# Altium schematic sheet p02_Power.SchDoc
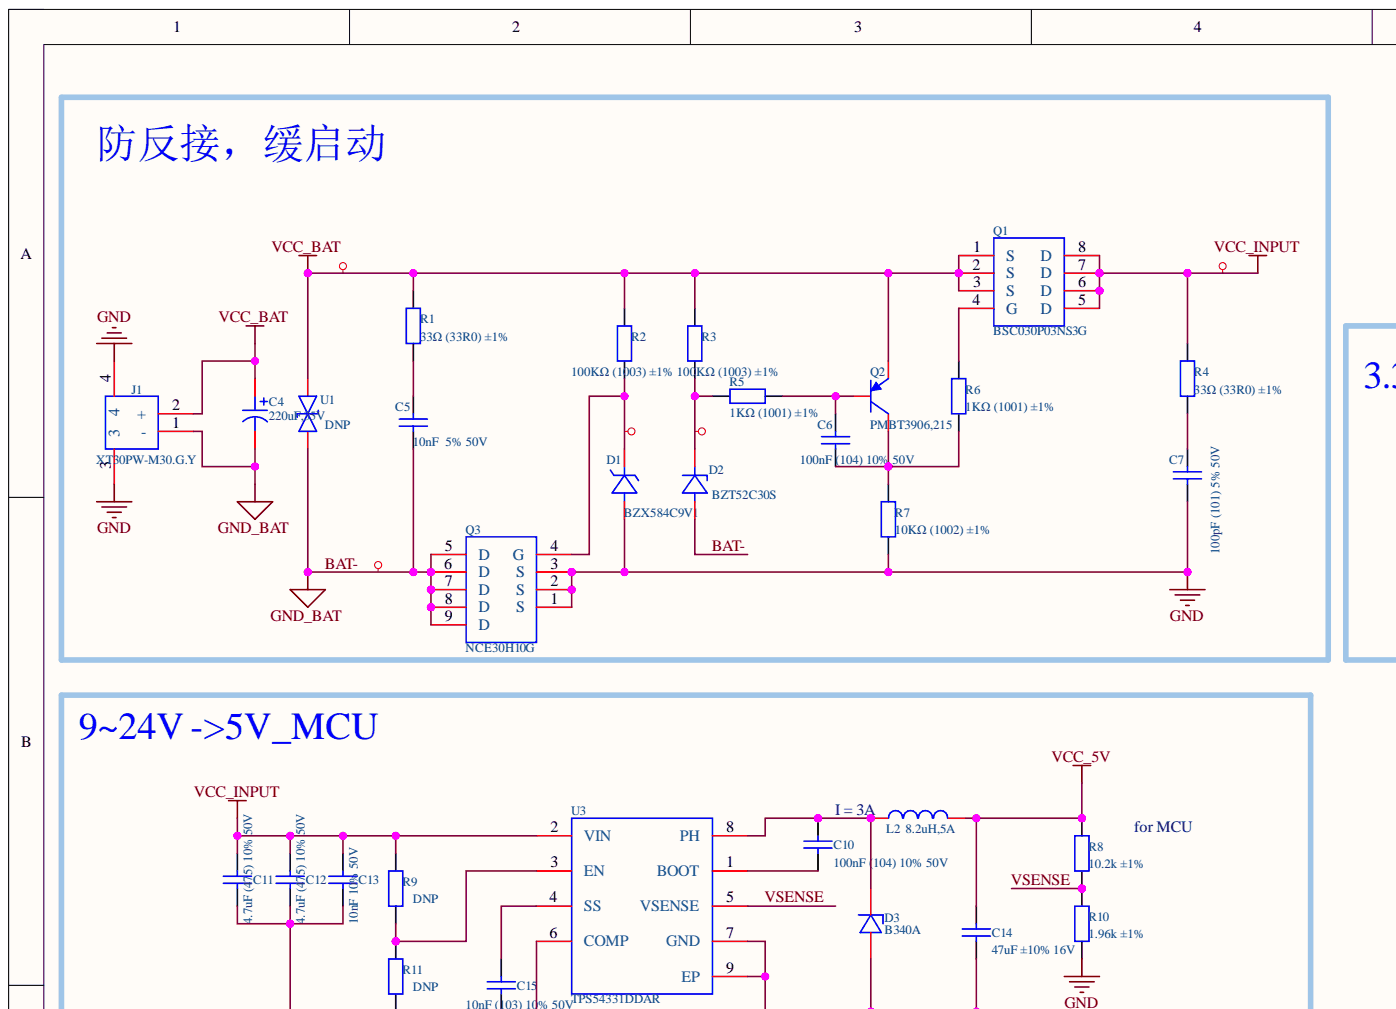
[diagram p0: p02_Power.SchDoc, top left]
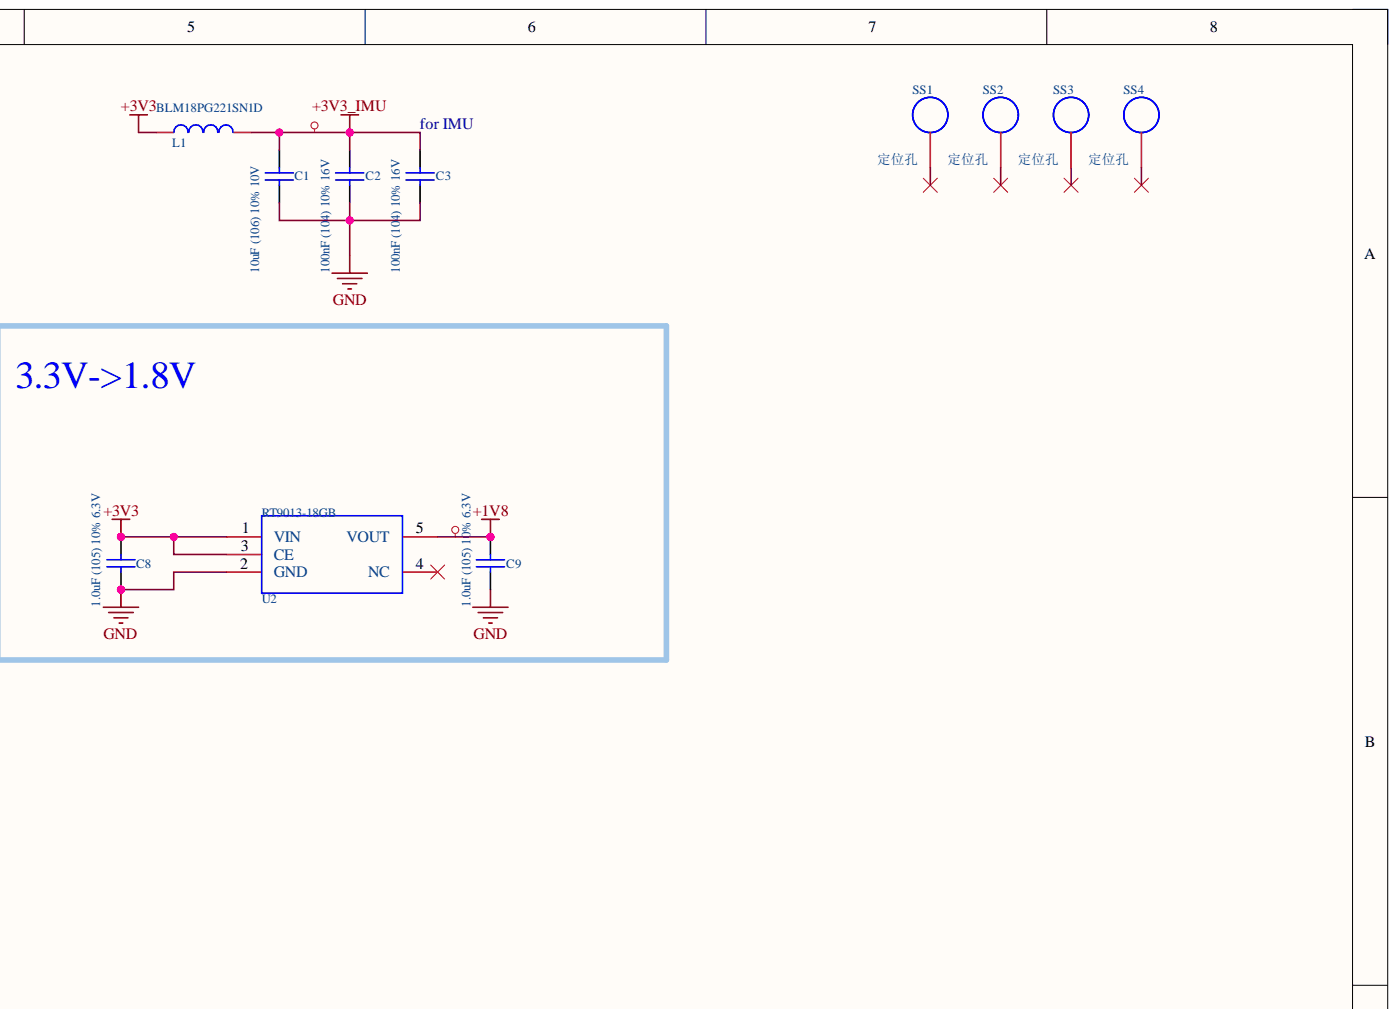
[diagram p1: p02_Power.SchDoc, top right]
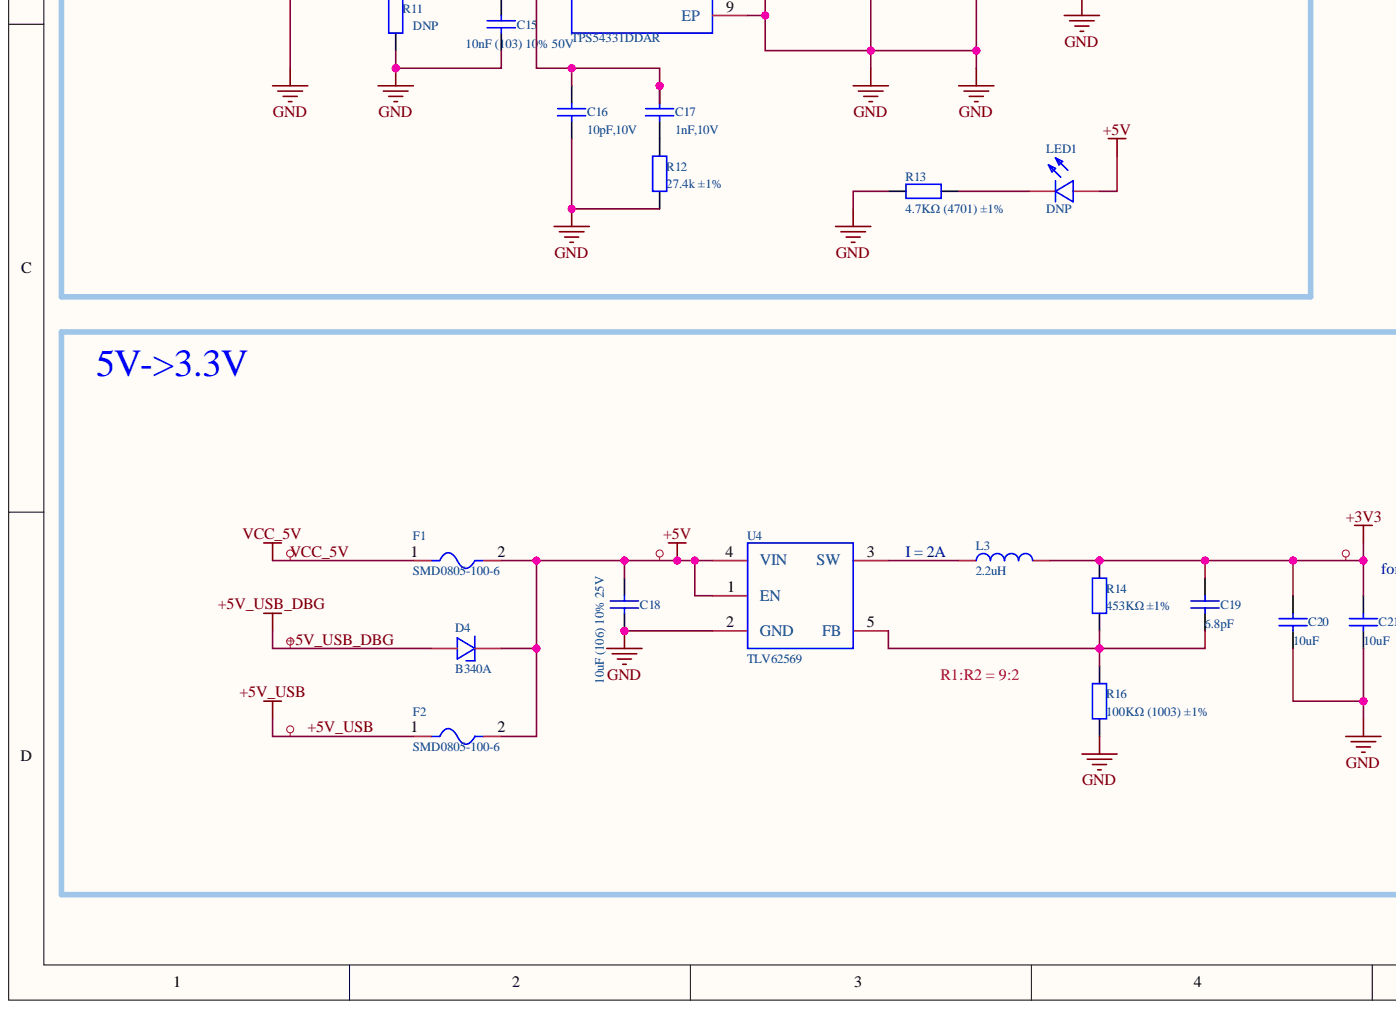
[diagram p2: p02_Power.SchDoc, bottom left]
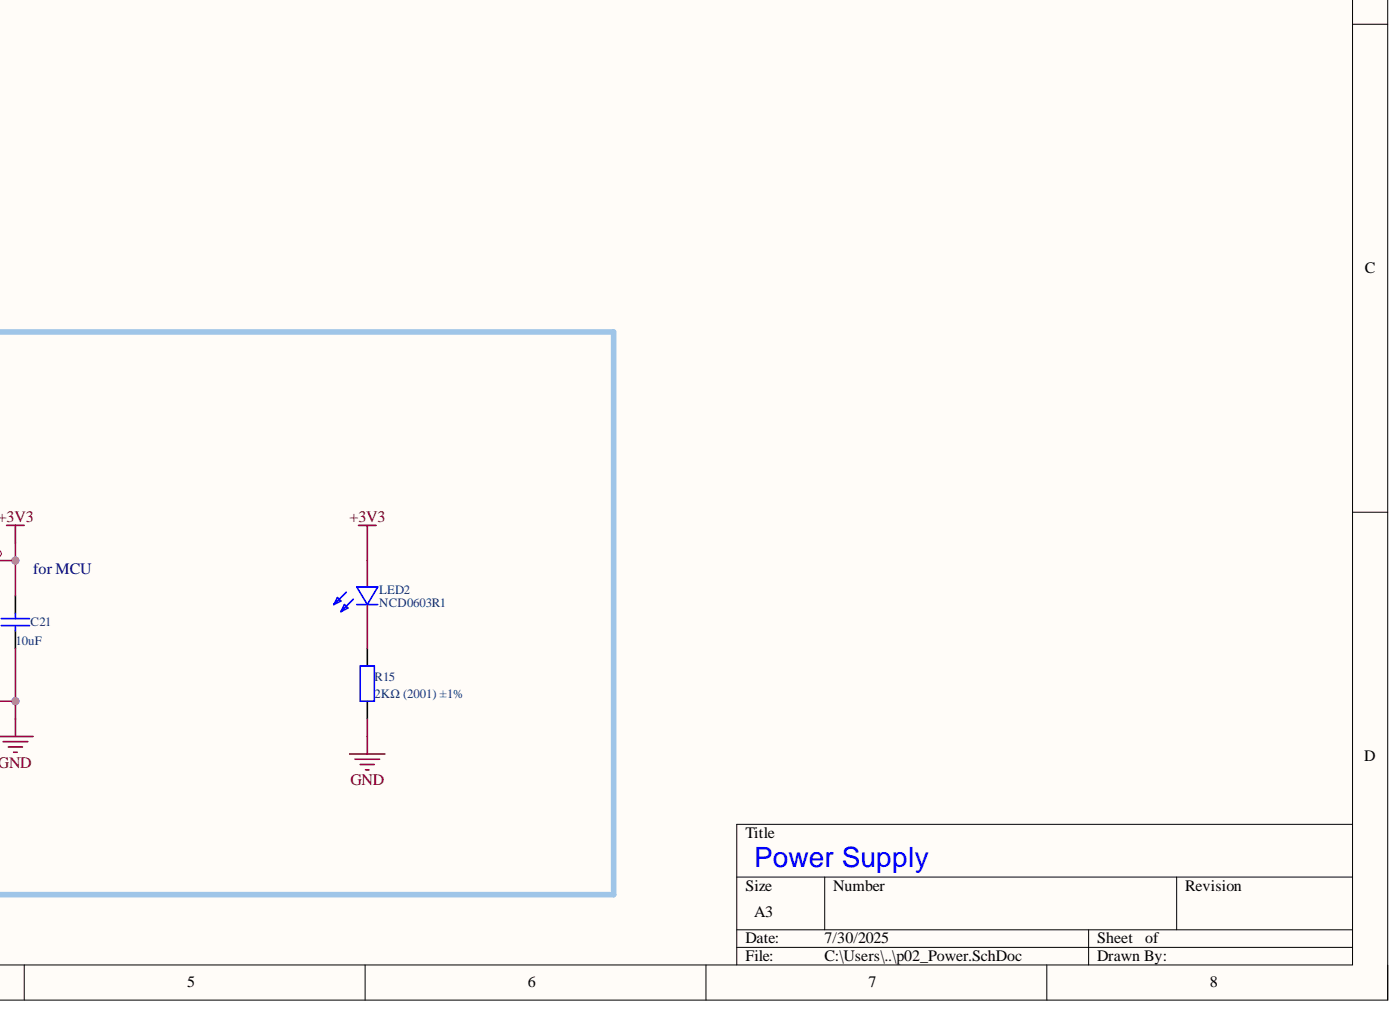
[diagram p3: p02_Power.SchDoc, bottom right]

## Parts
C1  10uF (106) 10% 10V  [C0603]
C2  100nF (104) 10% 16V  [C0402]
C3  100nF (104) 10% 16V  [C0402]
C4  220uF,35V  [CAP-SMD_BD6.3-L6.6-W6.6-FD]
C5  10nF  5% 50V  [C0402]
C6  100nF (104) 10% 50V  [C0603]
C7  100pF (101) 5% 50V  [C0402]
C8  1.0uF (105) 10% 6.3V  [C0402]
C9  1.0uF (105) 10% 6.3V  [C0402]
C10  100nF (104) 10% 50V  [C0603]
C11  4.7uF (475) 10% 50V  [C0603]
C12  4.7uF (475) 10% 50V  [C0603]
C13  10nF 10% 50V  [C0402]
C14  47uF ±10% 16V  [C1210]
C15  10nF (103) 10% 50V  [C0402]
C16  10pF,10V  [C0402]
C17  1nF,10V  [C0402]
C18  10uF (106) 10% 25V  [C0603]
C19  6.8pF  [C0402]
C20  10uF  [C0603]
C21  10uF  [C0603]
D1  BZX584C9V1  [SOD-523_L1.2-W0.8-LS1.6-FD]
D2  BZT52C30S  [SOD-323_L1.7-W1.3-LS2.5-RD-1]
D3  B340A  [SMA_L4.3-W2.6-LS5.1-RD]
D4  B340A  [SMA_L4.3-W2.6-LS5.1-RD]
F1  SMD0805-100-6  [F0805]
F2  SMD0805-100-6  [F0805]
J1  XT30PW-M30.G.Y  [CONN-TH_XT30PW-M]
L1  BLM18PG221SN1D  [L0603]
L2  8.2uH,5A  [IND-SMD_L7.0-W6.6_FXL0630]
L3  2.2uH  [IND-SMD_L2.5-W2.0_FTC252010S]
LED1  DNP  [LED0603-RD]
LED2  NCD0603R1  [LED0603-RD]
Q1  BSC030P03NS3G  [DFN-8_L6.0-W5.0-P1.27-LS6.2-BL]
Q2  PMBT3906,215  [SOT-23-3_L2.9-W1.3-P1.90-LS2.4-BR]
Q3  NCE30H10G  [PDFN-8_L5.8-W4.9-P1.27-LS6.1-BL]
R1  33Ω (33R0) ±1%  [R0402]
R2  100KΩ (1003) ±1%  [R0402]
R3  100KΩ (1003) ±1%  [R0402]
R4  33Ω (33R0) ±1%  [R0402]
R5  1KΩ (1001) ±1%  [R0402]
R6  1KΩ (1001) ±1%  [R0402]
R7  10KΩ (1002) ±1%  [R0402]
R8  10.2k ±1%  [R0402]
R9  DNP  [R0402]
R10  1.96k ±1%  [R0402]
R11  DNP  [R0402]
R12  27.4k ±1%  [R0402]
R13  4.7KΩ (4701) ±1%  [R0402]
R14  453KΩ ±1%  [R0402]
R15  2KΩ (2001) ±1%  [R0402]
R16  100KΩ (1003) ±1%  [R0402]
SS1  定位孔  [PTH-M25]  (no BOM)
SS2  定位孔  [PTH-M25]  (no BOM)
SS3  定位孔  [PTH-M25]  (no BOM)
SS4  定位孔  [PTH-M25]  (no BOM)
U1  DNP  [SMA_L4.3-W2.7-LS5.0-BI]
U2  RT9013-18GB  [SOT-23-5_L3.0-W1.7-P0.95-LS2.8-BR]
U3  TPS54331DDAR  [SOIC-8_L4.9-W3.9-P1.27-LS6.0-BL-EP]
U4  TLV62569  [SOT-23-5]

## Nets
+1V8: C9.2 U2.5(VOUT)
+3V3: C8.2 C19.2 C20.1 C21.1 L1.1 L3.2 LED2.2 R14.2 U2.1(VIN) U2.3(CE)
+3V3_IMU: C1.2 C2.2 C3.1 L1.2
+5V: C18.1 D4.2 F1.2 F2.2 LED1.2 U4.1(EN) U4.4(VIN)
GND: C1.1 C2.1 C3.2 C7.1 C8.1 C9.1 C11.1 C12.1 C13.1 C14.2 C15.1 C16.1 C18.2 C20.2 C21.2 D1.1 D3.1 J1.3 J1.4 Q3.1(S) Q3.2(S) Q3.3(S) R7.1 R10.1 R11.1 R12.1 R13.1 R15.1 R16.1 U2.2(GND) U3.7(GND) U3.9(EP) U4.2(GND)
VCC_BAT: C4.1 J1.2(+) Q1.1(S) Q1.2(S) Q1.3(S) Q2.2 R1.2 R2.2 R3.2 U1.2
VCC_INPUT: C11.2 C12.2 C13.2 Q1.5(D) Q1.6(D) Q1.7(D) Q1.8(D) R4.2 R9.2 U3.2(VIN)
+5V_USB: F2.1
+5V_USB_DBG: D4.1
BAT-: C4.2 C5.1 D2.2 J1.1(-) Q3.5(D) Q3.6(D) Q3.7(D) Q3.8(D) Q3.9(D) U1.1
VCC_5V: C14.1 F1.1 L2.2 R8.2
VSENSE: R8.1 R10.2 U3.5(VSENSE)
NetC5_2: C5.2 R1.1
NetC6_1: C6.1 Q2.3 R6.1 R7.2
NetC6_2: C6.2 Q2.1 R5.2
NetC7_2: C7.2 R4.1
NetC10_1: C10.1 D3.2 L2.1 U3.8(PH)
NetC10_2: C10.2 U3.1(BOOT)
NetC15_2: C15.2 U3.4(SS)
NetC16_2: C16.2 C17.2 U3.6(COMP)
NetC17_1: C17.1 R12.2
NetC19_1: C19.1 R14.1 R16.2 U4.5(FB)
NetD1_2: D1.2 Q3.4(G) R2.1
NetD2_1: D2.1 R3.1 R5.1
NetL3_1: L3.1 U4.3(SW)
NetLED1_1: LED1.1 R13.2
NetLED2_1: LED2.1 R15.2
NetQ1_4: Q1.4(G) R6.2
NetR9_1: R9.1 R11.2 U3.3(EN)

Not connected: SS1 0; SS2 0; SS3 0; SS4 0; U2 4(NC)

## Library data of the parts
C1 = 0603 10uF (106) 10% 10V: 贴片电容; Component Kind: Standard; ComponentLink1Description: 供应商链接; ComponentLink1URL: http://www.szlcsc.com/product/details_20411.html; Library Name: Cap.SchLib; Package: 0603; Supplier: LC; SuppliersPartNumber: C19702
C2 C3 = 0402 100nF (104) 10% 16V: 贴片电容; Component Kind: Standard; ComponentLink1Description: 供应商链接; ComponentLink1URL: https://item.szlcsc.com/42839.html; Library Name: Cap.SchLib; Package: 0402; Supplier: LC; SuppliersPartNumber: C1525
C4 = 189RV0019: 220uF,35V,189RV0019; Manufacturer: KNSCHA(科尼盛); Add into BOM: yes; Device: RVT220UF50V67RV0021; Lifetime: 2000hrs@105℃; Ripple Current: 230mA@120Hz; Tolerance: ±20%; Voltage Rating: 50V; Component Kind: Standard; Convert to PCB: yes; Datasheet: https://atta.szlcsc.com/upload/public/pdf/source/20210824/08E695BB4B7EEB96F38ACC5D13E6017A.pdf; Diameter: 10mm; Equivalent Series Resistance(ESR): -; Height - Seated (Max): 10.2mm; JLCPCB Part Class: Extended Part; LCSC Part Name: 220uF ±20% 50V; Library Name: ra8p1_v0.2.SCHLIB; Manufacturer Part: RVT220UF50V67RV0021; Operating Temperature: -55℃~+105℃; PCB3D: CAP-SMD_BD10.0-L10.3-W10.3-H10.2; Supplier: LCSC; Supplier Footprint: SMD,D10xL10.2mm; Supplier Part: C2887271; Symbol: RVT220UF50V67RV0021; Value: 220uF
C5 = 0402 100pF (101) 5% 50V: 贴片电容; Component Kind: Standard; ComponentLink1Description: 供应商链接; ComponentLink1URL: https://item.szlcsc.com/1876.html?fromZone=l_b__%2522brand%2522&spm=sc.bid.xh1.zy.n&lcsc_vid=FANcVFBQFQMNX1cFFAdZVVZXEgdeAgdWFlQNUVAHT1ExVlNSRFhXVlNRRlJaUTsOAxUeFF5JWA0HFBBIBBsCBBcFWQIBCksEBQAAAzsWFgYLSFJVWQkaCgg%3D; Library Name: Cap.SchLib; Package: 0402; Supplier: LC; SuppliersPartNumber: C1546
C6 = 0402 100nF (104) 10% 50V: GRM155R71H104KE14D,100nF (104) 10% 50V; Component Kind: Standard; ComponentLink1Description: 供应商链接; ComponentLink1URL: https://item.szlcsc.com/78147.html?fromZone=l_c__%2522catalog%2522&spm=sc.cid.xh1.zy.n___sc.gb.xh1.zy.l&lcsc_vid=FgdZAQZURgVfVlRQQQNbBAcFQVZYX1NeQ1BYX10ARFkxVlNSQ1FZUVReQVdaUjsOAxUeFF5JWAgaAglIBBsCBBcFWQIBCks%3D; Library Name: Cap.SchLib; Package: 0603; Supplier: LC; SuppliersPartNumber: C1525
C7 = 0402 100pF (101) 5% 50V: 贴片电容,GRM1555C1H101JA01D; Component Kind: Standard; ComponentLink1Description: 供应商链接; ComponentLink1URL: https://item.szlcsc.com/78305.html?fromZone=s_s__%2522100pF%2520%28101%29%25205%252525%252050V%2522&spm=sc.gb.xh1.zy.n___sc.it.hd.ss&lcsc_vid=QwANAgdTElRYBVwHQQILVgAAQwJZVlVSFlZXBVEEQlMxVlNSTldfVlFUQldaVDtW; Library Name: Cap.SchLib; Package: 0402; Supplier: LC; SuppliersPartNumber: C77177
C8 C9 = 0402 1.0uF (105) 10% 25V: 贴片电容,X7R; Component Kind: Standard; ComponentLink1Description: 供应商链接; ComponentLink1URL: https://item.szlcsc.com/291101.html?fromZone=l_b__%2522brand%2522&spm=sc.bid.xh6.zy.n&lcsc_vid=FANcVFBQFQMNX1cFFAdZVVZXEgdeAgdWFlQNUVAHT1ExVlNSRFhXVl1TRlldVTsOAxUeFF5JWA0HFBBIBBsCBBcFWQIBCksEBQAAAzsWFgYLSFZTQ08GEwkK; Library Name: Cap.SchLib; Package: 0402; Supplier: LC; SuppliersPartNumber: C52923
C10 = 0603 100nF (104) 10% 50V: GRM155R71H104KE14D,100nF (104) 10% 50V; Component Kind: Standard; ComponentLink1Description: 供应商链接; ComponentLink1URL: http://www.szlcsc.com/product/details_15331.html; Library Name: Cap.SchLib; Package: 0603; Supplier: LC; SuppliersPartNumber: C14663
C11 C12 = 0603 4.7uF (475) 10% 50V: 贴片电容; Component Kind: Standard; ComponentLink1Description: 供应商链接; ComponentLink1URL: https://item.szlcsc.com/1973.html; Library Name: ra8p1_v0.2.SCHLIB; Package: 0603; Supplier: LC; SuppliersPartNumber: C19666
C13 = 0603 100nF (104) 10% 50V: 贴片电容，CL05B103KB5NNNC; Component Kind: Standard; ComponentLink1Description: 供应商链接; ComponentLink1URL: http://www.szlcsc.com/product/details_15331.html; Library Name: Cap.SchLib; Package: 0603; Supplier: LC; SuppliersPartNumber: C14663
C14 = 0603 100nF (104) 10% 50V: GRM32ER61C476KE15L,贴片电容; Component Kind: Standard; ComponentLink1Description: 供应商链接; ComponentLink1URL: http://www.szlcsc.com/product/details_15331.html; Library Name: Cap.SchLib; Package: 1210; Supplier: LC; SuppliersPartNumber: C14663
C15 = 0402 10nF (103) 10% 50V: 贴片电容; Component Kind: Standard; ComponentLink1Description: 供应商链接; ComponentLink1URL: http://www.szlcsc.com/product/details_15869.html; Library Name: Cap.SchLib; Package: 0402; Supplier: LC; SuppliersPartNumber: C15195
C16 = 0402 4.7uF (475) 20% 10V: 贴片电容; Component Kind: Standard; ComponentLink1Description: 供应商链接; ComponentLink1URL: http://www.szlcsc.com/product/details_24469.html; Library Name: ra8p1_v0.1.SCHLIB; Package: 0402; Supplier: LC; SuppliersPartNumber: C23733
C17 = 0402 4.7uF (475) 20% 10V: 贴片电容,GRM1555C1H102JA01D; Component Kind: Standard; ComponentLink1Description: 供应商链接; ComponentLink1URL: http://www.szlcsc.com/product/details_24469.html; Library Name: ra8p1_v0.1.SCHLIB; Package: 0402; Supplier: LC; SuppliersPartNumber: C23733
C18 C20 C21 = 0603 10uF (106) 10% 10V: 贴片电容，GRM188R61E106KA73D，0603; Component Kind: Standard; ComponentLink1Description: 供应商链接; ComponentLink1URL: http://www.szlcsc.com/product/details_20411.html; Library Name: Cap.SchLib; Package: 0603; Supplier: LC; SuppliersPartNumber: C19702
C19 = 0402 6.8pF (6R8) 50V: 贴片电容; Component Kind: Standard; ComponentLink1Description: 供应商链接; ComponentLink1URL: https://item.szlcsc.com/90076.html?fromZone=s_s__%25226.8pF%2520(6R8)%25200.25pF%252050V%2522&spm=sc.gbn.xh1.zy.n&lcsc_vid=RwBfVQZSEwJZV1NUEVEPX1cHQQNZXgUCFFhXBVwEFFcxVlNTR1lfUVZSQ1NaVzsOAxUeFF5JWBIBSRccGwIdBEoFGAxBAAgJFQACSQwSGg0%3D; Library Name: ra8p1_v0.2.SCHLIB; Package: 0402; Supplier: LC; SuppliersPartNumber: C88896
D1 = BZX584C9V1_C19077465: Manufacturer: 宏嘉诚; Add into BOM: yes; Diode Configuration: 独立式; Impedance(Zzt): 15Ω; Pd - Power Dissipation(Pd): 150mW; Reverse Leakage Current (Ir): 500nA@6V; Zener Voltage(Nom): 9.1V; Zener Voltage(Range): 8.5V~9.6V; Component Kind: Standard; Convert to PCB: yes; Datasheet: https://atta.szlcsc.com/upload/public/pdf/source/20250106/C98AF225AFE663ECDED504B7F0E6FF74.pdf; Device: BZX584C9V1_C19077465; JLCPCB Part Class: Extended Part; LCSC Part Name: BZX584C9V1; Library Name: ra8p1_v0.1.SCHLIB; Manufacturer Part: BZX584C9V1; PCB3D: SOD-523_L1.2-W0.8-H0.7-LS1.6; Supplier: LCSC; Supplier Footprint: SOD-523; Supplier Part: C19077465; Symbol: BZX584C9V1_C19077465
D2 = BZT52C30S_C22375291: 稳压二极管 28-32V; Manufacturer: 宏迦橙; Add into BOM: yes; Diode Configuration: 独立式; Power Dissipation: 200mW; Reverse Leakage Current (Ir): 100nA@21V; Zener Impedance (Zzt): 300Ω; Zener Voltage (Nom): 30V; Zener Voltage (Range): 28V~32V; Component Kind: Standard; Convert to PCB: yes; Datasheet: https://atta.szlcsc.com/upload/public/pdf/source/20240429/C1A7EBCB011A00C85537BE0DDA8719E4.pdf; Device: BZT52C30S_C22375291; JLCPCB Part Class: Extended Part; LCSC Part Name: BZT52C30S; Library Name: ra8p1_v0.1.SCHLIB; Manufacturer Part: BZT52C30S; PCB3D: SOD-323_L1.7-W1.3-LS2.5-RD-1; Supplier: LCSC; Supplier Footprint: SOD-323; Supplier Part: C22375291; Symbol: BZT52C30S_C22375291
D3 D4 = B340A: 40V,550mV@3A; Manufacturer: MDD(辰达半导体); Manufacturer Part: SS54; Add into BOM: yes; Diode Configuration: 独立式; Forward Voltage (Vf@If): 550mV@5.0A; Rectified Current: 5A; Reverse Leakage Current (Ir): 50uA@40V; Component Kind: Standard; Convert to PCB: yes; Datasheet: https://atta.szlcsc.com/upload/public/pdf/source/20230828/254C3882F8647358AD9F60DD73611B5E.pdf; Device: B340A; JLCPCB Part Class: Extended Part; LCSC Part Name: 电压:40V 电流:3A; Library Name: ra8p1_v0.1.SCHLIB; PCB3D: SMA_L4.3-W2.6-LS5.0-FD; Reverse Voltage (Vr): 40V; Supplier: LCSC; Supplier Footprint: SMA(DO-214AC); Symbol: B340A
F1 F2 = SMD0805-050-6: Manufacturer: 宏嘉诚; Add into BOM: yes; Current - Max: 40A; Hold Current: 500mA; Power Dissipation: 500mW; Resistance - Initial (Ri) (Min): 100mΩ; Resistance - Post Trip (R1) (Max): 850mΩ; Time to Trip(Max): 100ms; Trip Current: 1A; Voltage - Max: 6V; Component Kind: Standard; Convert to PCB: yes; Datasheet: https://atta.szlcsc.com/upload/public/pdf/source/20250414/C7C75E40B18789A4A1352E9E468D2637.pdf; Device: SMD0805-050-6; JLCPCB Part Class: Extended Part; LCSC Part Name: SMD0805-050-6; Library Name: ra8p1_v0.2.SCHLIB; Manufacturer Part: SMD0805-050-6; PCB3D: F0805_L2.1-W1.4-H0.8; Supplier: LCSC; Supplier Footprint: 0805; Supplier Part: C46640983; Symbol: SMD0805-050-6
J1 = XT30PW-M30.G.Y: Manufacturer: AMASS(艾迈斯); Add into BOM: yes; contact resistance: 1.2mΩ; InterfaceType: 公头; RatedCurrent: 15A; RatedVoltage: DC 500V; RecommendedWireGauge: 16/18/20AWG; specifications: 2pin; Belt length: -; Colour: -; Component Kind: Standard; Convert to PCB: yes; Datasheet: https://atta.szlcsc.com/upload/public/pdf/source/20220222/6A9F22F7850E25B33E1BE430ADF8560B.pdf; Device: XT30PW-M30.G.Y; FlameRetardantGrade: -; Input: -; InsulationMaterial: -; Interface: -; JLCPCB Part Class: Extended Part; LCSC Part Name: AMASS(艾迈斯)航模插头 卧式弯脚 XT30PW-M公头; Library Name: ra8p1_v0.1.SCHLIB; Manufacturer Part: XT30PW-M30.G.Y; MaximumCurrent: -; MetalMaterial: -; NumberOfUSBHoles: -; Output: -; PCB3D: CONN-TH_XT30PW-M; Power: -; Size: -; Supplier: LCSC; Supplier Footprint: -; Supplier Part: C431092; Symbol: XT30PW-M; Type: 航模插头; Type1: -
L1 = BLM18PG221SN1D: 磁珠，0603，1.4A; Manufacturer: muRata(村田); Add into BOM: yes; Circuits: 1; Current Rating: 1.4A; DC Resistance: 100mΩ; Impedance @ Frequency: 220Ω@100MHz; Tolerance: ±25%; Component Kind: Standard; Convert to PCB: yes; Datasheet: https://atta.szlcsc.com/upload/public/pdf/source/20191226/C476007_90F4628D6A1A8703036BE981B9833918.pdf; Device: BLM18PG221SN1D; JLCPCB Part Class: Extended Part; LCSC Part Name: 单路 0603磁珠 阻抗220Ω@100MHz; Library Name: ra8p1_v0.1.SCHLIB; Manufacturer Part: BLM18PG221SN1D; PCB3D: L0603_L1.6-W0.8-H0.5_BEAD; Supplier: LCSC; Supplier Footprint: 0603; Supplier Part: C80165; Symbol: BLM18PG221SN1D
L2 = FXL0630-8R2-M: 8.2UH; Manufacturer: cjiang(长江微电); Add into BOM: yes; DC Resistance (DCR): 60mΩ; Saturation Current (Isat): 6A; Tolerance: ±20%; Type: 一体成型电感; Component Kind: Standard; Convert to PCB: yes; Datasheet: https://atta.szlcsc.com/upload/public/pdf/source/20240426/3189EA8206330E2108542F9E34E540E7.pdf; Device: FXL0630-8R2-M; JLCPCB Part Class: Extended Part; LCSC Part Name: 8.2uH ±20% 6A; Library Name: ra8p1_v0.1.SCHLIB; Manufacturer Part: FXL0630-8R2-M; PCB3D: IND-SMD_L7.0-W6.6-H2.8_FXL0630; Rated Current: 5A; Supplier: LCSC; Supplier Footprint: SMD,6.6x7mm; Supplier Part: C167222; Symbol: FXL0630-8R2-M; Value: 8.2uH
L3 = FTC252010S2R2MBCA: 2.4A ,62mΩ; Manufacturer: cjiang(长江微电); Add into BOM: yes; Saturation Current (Isat): 3.3A; Tolerance: ±20%; Type: 一体成型电感; Component Kind: Standard; Convert to PCB: yes; Datasheet: https://atta.szlcsc.com/upload/public/pdf/source/20230602/8FFEDA9C0247A92A0D4B67554BFE4091.pdf; DC Resistance (DCR): 62mΩ; Device: FTC252010S2R2MBCA; JLCPCB Part Class: Extended Part; LCSC Part Name: 2.2uH ±20% 3.3A; Library Name: ra8p1_v0.1.SCHLIB; Manufacturer Part: FTC252010S2R2MBCA; PCB3D: IND-SMD_L2.5-W2.0_FTC252010S; Rated Current: 2.4A; Supplier: LCSC; Supplier Footprint: SMD,2x2.5mm; Supplier Part: C5832358; Symbol: FTC252010S2R2MBCA; Value: 2.2uH
LED1 = LED0603RED: RED,0603，NCD0603R1; Manufacturer: 国星光电; Add into BOM: yes; Device: NCD0603R1_C84263; Diode Configuration: 独立二极管; Forward Current: 25mA; Illumination Color: 红色; Luminous Intensity: 80mcd~220mcd; Power(Watts): 65mW; Symbol: FC-DA1608HRK-620D; Viewing Angle: 130°; Voltage - Forward(Vf): 1.6V~2.6V; Wavelength - Dominant: 615nm~630nm; Color Temperature: -; Component Kind: Standard; Convert to PCB: yes; Datasheet: https://atta.szlcsc.com/upload/public/pdf/source/20200820/C84263_DABC6A75E58F576973B407FDBA283D60.pdf; JLCPCB Part Class: Extended Part; Lamp Holder Type: -; LCSC Part Name: NCD0603R1; Lens Color: -; Library Name: ra8p1_v0.1.SCHLIB; Manufacturer Part: NCD0603R1; Mounting Sytle: -; Operating Temperature: -30℃~+85℃; PCB3D: LED0603-RD_RED; Peak Wavelength: -; Supplier: LCSC; Supplier Footprint: 0603; Supplier Part: C84263
LED2 = LED0603RED: RED,0603; Manufacturer: 国星光电; Add into BOM: yes; Device: NCD0603R1_C84263; Diode Configuration: 独立二极管; Forward Current: 25mA; Illumination Color: 红色; Luminous Intensity: 80mcd~220mcd; Power(Watts): 65mW; Symbol: FC-DA1608HRK-620D; Viewing Angle: 130°; Voltage - Forward(Vf): 1.6V~2.6V; Wavelength - Dominant: 615nm~630nm; Color Temperature: -; Component Kind: Standard; Convert to PCB: yes; Datasheet: https://atta.szlcsc.com/upload/public/pdf/source/20200820/C84263_DABC6A75E58F576973B407FDBA283D60.pdf; JLCPCB Part Class: Extended Part; Lamp Holder Type: -; LCSC Part Name: NCD0603R1; Lens Color: -; Library Name: ra8p1_v0.1.SCHLIB; Manufacturer Part: NCD0603R1; Mounting Sytle: -; Operating Temperature: -30℃~+85℃; PCB3D: LED0603-RD_RED; Peak Wavelength: -; Supplier: LCSC; Supplier Footprint: 0603; Supplier Part: C84263
Q1 = FDMS6681Z-HXY: PMOS,30V,100A; Manufacturer: HXY MOSFET(华轩阳电子); Add into BOM: yes; Drain Source On Resistance (RDS(on)@Vgs,Id): 4mΩ@10V,15A; Gate Threshold Voltage (Vgs(th)@Id): 1.2V@250uA; Input Capacitance (Ciss@Vds): 3.45nF@25V; Power Dissipation (Pd): 120W; Reverse Transfer Capacitance (Crss@Vds): 140pF@25V; Total Gate Charge (Qg@Vgs): 60nC@10V; Type: 1个P沟道; Component Kind: Standard; Continuous Drain Current (Id): 100A; Convert to PCB: yes; Datasheet: https://atta.szlcsc.com/upload/public/pdf/source/20240325/6C1990F0066A2949D2CC4CDA05A7E8EE.pdf; Device: FDMS6681Z-HXY; Drain Source Voltage (Vdss): 30V; JLCPCB Part Class: Extended Part; LCSC Part Name: 1个P沟道 耐压:30V; Library Name: ra8p1_v0.1.SCHLIB; Manufacturer Part: FDMS6681Z-HXY; Operating Temperature: -55℃~+150℃; PCB3D: DFN-8_L6.0-W5.0-P1.27-LS6.2-BL; Supplier: LCSC; Supplier Footprint: DFN-8L(5x6); Supplier Part: C5148667; Symbol: FDMS6681Z-HXY
Q2 = PMBT3906,215: PNP; Manufacturer: Nexperia(安世); Add into BOM: yes; Collector Current (Ic): 200mA; Collector Cut-Off Current (Icbo): 50nA; Collector-Emitter Breakdown Voltage (Vceo): 40V; Collector-Emitter Saturation Voltage (VCE(sat)@Ic,Ib): 250mV@10mA,1mA; DC Current Gain (hFE@Ic,Vce): 60@0.1mA,1V; Power Dissipation (Pd): 250mW; Transition Frequency (fT): 250MHz; Component Kind: Standard; Convert to PCB: yes; Datasheet: https://atta.szlcsc.com/upload/public/pdf/source/20200610/C552713_3A9BABDB448860F6CA5CA955E8F05794.pdf; Device: PMBT3906,215; JLCPCB Part Class: Extended Part; LCSC Part Name: PNP 电流:200mA 耐压:40V; Library Name: ra8p1_v0.1.SCHLIB; Manufacturer Part: PMBT3906,215; Operating Temperature: -65℃~+150℃; PCB3D: SOT-23-3P_L2.9-W1.3-H1.0-LS2.4-P0.95; Supplier: LCSC; Supplier Footprint: SOT-23; Supplier Part: C8670; Symbol: PMBT3906,215; Transistor Type: PNP
Q3 = NCE30H10G: NMOS,30V,100A; Manufacturer: NCE(无锡新洁能); Add into BOM: yes; Drain Source On Resistance (RDS(on)@Vgs,Id): 1.9mΩ@10V; Gate Threshold Voltage (Vgs(th)@Id): 1.2V@250uA; Input Capacitance (Ciss@Vds): 6.268nF@15V; Power Dissipation (Pd): 65W; Reverse Transfer Capacitance (Crss@Vds): 563pF@15V; Total Gate Charge (Qg@Vgs): 115nC@15V; Type: 1个N沟道; Component Kind: Standard; Continuous Drain Current (Id): 100A; Convert to PCB: yes; Datasheet: https://atta.szlcsc.com/upload/public/pdf/source/20220621/DE1A0DD93CD0077AD45AFB51E719E4DB.pdf; Device: NCE30H10G; Drain Source Voltage (Vdss): 30V; JLCPCB Part Class: Extended Part; LCSC Part Name: 1个N沟道 耐压:30V 电流:100A; Library Name: ra8p1_v0.1.SCHLIB; Manufacturer Part: NCE30H10G; Operating Temperature: -55℃~+150℃; PCB3D: PDFN-8_L5.8-W4.9-P1.27-LS6.1-BL; Supplier: LCSC; Supplier Footprint: PDFN-8(5.1x5.8); Supplier Part: C502869; Symbol: NCE30H10G
R1 R4 = 0402 33Ω (33R0) 1%: 贴片电阻; Component Kind: Standard; ComponentLink1Description: 供应商链接; ComponentLink1URL: http://www.szlcsc.com/product/details_25848.html; Library Name: RES.SchLib; Package: 0402; Supplier: LC; SuppliersPartNumber: C25105
R2 R3 R16 = 0402 100KΩ (1003) 1%: 贴片电阻; Component Kind: Standard; ComponentLink1Description: 供应商链接; ComponentLink1URL: http://www.szlcsc.com/product/details_26484.html; Library Name: RES.SchLib; Package: 0402; Supplier: LC; SuppliersPartNumber: C25741
R5 R6 = 0402 1KΩ (1001) 1%: 贴片电阻; Component Kind: Standard; ComponentLink1Description: 供应商链接; ComponentLink1URL: http://www.szlcsc.com/product/details_12256.html; Library Name: RES.SchLib; Package: 0402; Supplier: LC; SuppliersPartNumber: C11702
R7 = 0402 10KΩ (1002) 1%: 贴片电阻，10K; Component Kind: Standard; ComponentLink1Description: 供应商链接; ComponentLink1URL: https://item.szlcsc.com/26487.html?fromZone=l_b__%2522brand%2522&spm=sc.bid.xh1.zy.n&lcsc_vid=FANcVFBQFQMNX1cFFAdZVVZXEgdeAgdWFlQNUVAHT1ExVlNSRFhXVlJQQFlYVzsOAxUeFF5JWA0HFBBIBBsCBBcFWQIBCksEBQAAA0tfTk8GEwkK; Library Name: RES.SchLib; Package: 0402; Supplier: LC; SuppliersPartNumber: C25744
R8 R10 R12 = 0402 1.96K ohm 1%: 贴片电阻; Component Kind: Standard; ComponentLink1Description: 供应商链接; ComponentLink1URL: http://www.szlcsc.com/product/details_26512.html; Library Name: ra8p1_v0.1.SCHLIB; Package: 0402; Supplier: LC; SuppliersPartNumber: C25769
R9 = 0402 1.96K ohm 1%: 贴片电阻,332K; Component Kind: Standard; ComponentLink1Description: 供应商链接; ComponentLink1URL: http://www.szlcsc.com/product/details_26512.html; Library Name: ra8p1_v0.1.SCHLIB; Package: 0402; Supplier: LC; SuppliersPartNumber: C25769
R11 = 0402 1.96K ohm 1%: 贴片电阻,61.8K; Component Kind: Standard; ComponentLink1Description: 供应商链接; ComponentLink1URL: http://www.szlcsc.com/product/details_26512.html; Library Name: ra8p1_v0.1.SCHLIB; Package: 0402; Supplier: LC; SuppliersPartNumber: C25769
R13 = 0402 4.7KΩ (4701) 1%: 贴片电阻; Component Kind: Standard; ComponentLink1Description: 供应商链接; ComponentLink1URL: http://www.szlcsc.com/product/details_26643.html; Library Name: RES.SchLib; Package: 0402; Supplier: LC; SuppliersPartNumber: C25900
R14 = 0402 470KΩ (4703) 1%: 贴片电阻; Component Kind: Standard; ComponentLink1Description: 供应商链接; ComponentLink1URL: http://www.szlcsc.com/product/details_26533.html; Library Name: RES.SchLib; Package: 0402; Supplier: LC; SuppliersPartNumber: C25790
R15 = 0402 2KΩ (2001) 1%: 贴片电阻; Component Kind: Standard; ComponentLink1Description: 供应商链接; ComponentLink1URL: http://www.szlcsc.com/product/details_4516.html; Library Name: RES.SchLib; Package: 0402; Supplier: LC; SuppliersPartNumber: C4109
SS1-SS4 = 定位孔: 定位孔,5.5mm; Library Name: ra8p1_v0.1.SCHLIB
U1 = SMAJ28CA_C908827: TVS-ESD,SMAJ28CA(NC); Manufacturer: GOODWORK(固得沃克); Add into BOM: yes; Clamping Voltage: 45.4V; Peak Pulse Current (Ipp): 8.8A; Peak Pulse Power Dissipation (Ppp): 400W; Reverse Leakage Current (Ir): 5uA; Reverse Stand-Off Voltage (Vrwm): 28V; Voltage - Breakdown: 31.1V; Component Kind: Standard; Convert to PCB: yes; Datasheet: https://atta.szlcsc.com/upload/public/pdf/source/20240508/8B44FA02DF89A45C8C3EB0325515EF7C.pdf; Device: SMAJ28CA_C908827; JLCPCB Part Class: Extended Part; LCSC Part Name: 双向TVS 28V截止 8.8A峰值脉冲; Library Name: ra8p1_v0.1.SCHLIB; Manufacturer Part: SMAJ28CA; Operating Temperature: -55℃~+150℃@(Tj); PCB3D: SMA_L4.3-W2.7-LS5.0-BI; Supplier: LCSC; Supplier Footprint: SMA(DO-214AC); Supplier Part: C908827; Symbol: SMAJ28CA_C908827; Type: TVS
U2 = RT9013-18GB_C22435116: LDO; Manufacturer: TECH PUBLIC(台舟); Add into BOM: yes; Number of Outputs: 1; Output Configuration: 正极; Output Current: 500mA; Output Type: 固定; Output Voltage: 1.8V; Power Supply Rejection Ratio (PSRR): 75dB@(1kHz); Voltage - Supply: 7V; Component Kind: Standard; Convert to PCB: yes; Datasheet: https://atta.szlcsc.com/upload/public/pdf/source/20240521/96856EEC3938E9CD57929E5A61E5793B.pdf; Device: RT9013-18GB_C22435116; JLCPCB Part Class: Extended Part; LCSC Part Name: RT9013-18GB; Library Name: ra8p1_v0.1.SCHLIB; Manufacturer Part: RT9013-18GB; Operating Temperature: -40℃~+85℃@(Ta); PCB3D: SOT-23-5_L2.9-W1.6-H1.1-LS2.8-P0.95; Supplier: LCSC; Supplier Footprint: SOT-23-5; Supplier Part: C22435116; Symbol: RT9013-18GB_C22435116
U3 = TPS54331DDAR: DC-DC; Manufacturer: TI(德州仪器); Add into BOM: yes; Function: 降压型; Input Voltage: 3.5V~28V; Number of Outputs: 1; Output Current: 3A; Output Type: 可调; Output Voltage: 800mV~3.3V; Switch tube (built-in/external): 内置; Switching Frequency: 570kHz; Synchronous Rectification: 否; Topology: 降压式; Component Kind: Standard; Convert to PCB: yes; Datasheet: https://www.ti.com/cn/lit/gpn/tps54331; Device: TPS54331DDAR; JLCPCB Part Class: Extended Part; LCSC Part Name: 28V输入、3A输出非同步降压转换器; Library Name: ra8p1_v0.1.SCHLIB; Manufacturer Part: TPS54331DDAR; Operating Temperature: -40℃~+150℃; PCB3D: SOIC-8_L4.9-W3.9-H1.7-LS6.0-P1.27; Quiescent Current (Iq): -; Supplier: LCSC; Supplier Footprint: SOIC-8-EP; Supplier Part: C90761; Symbol: TPS54331DDAR
U4 = TLV62569: TLV62569 2A, 5.5V, 1.5MHz STEP-DOWN CONVERTER IN A TSOT23-5; Component Kind: Standard; Library Name: ra8p1_v0.2.SCHLIB
